# Altium schematic sheet 5V_Efuses.SchDoc(5V_Efuses)
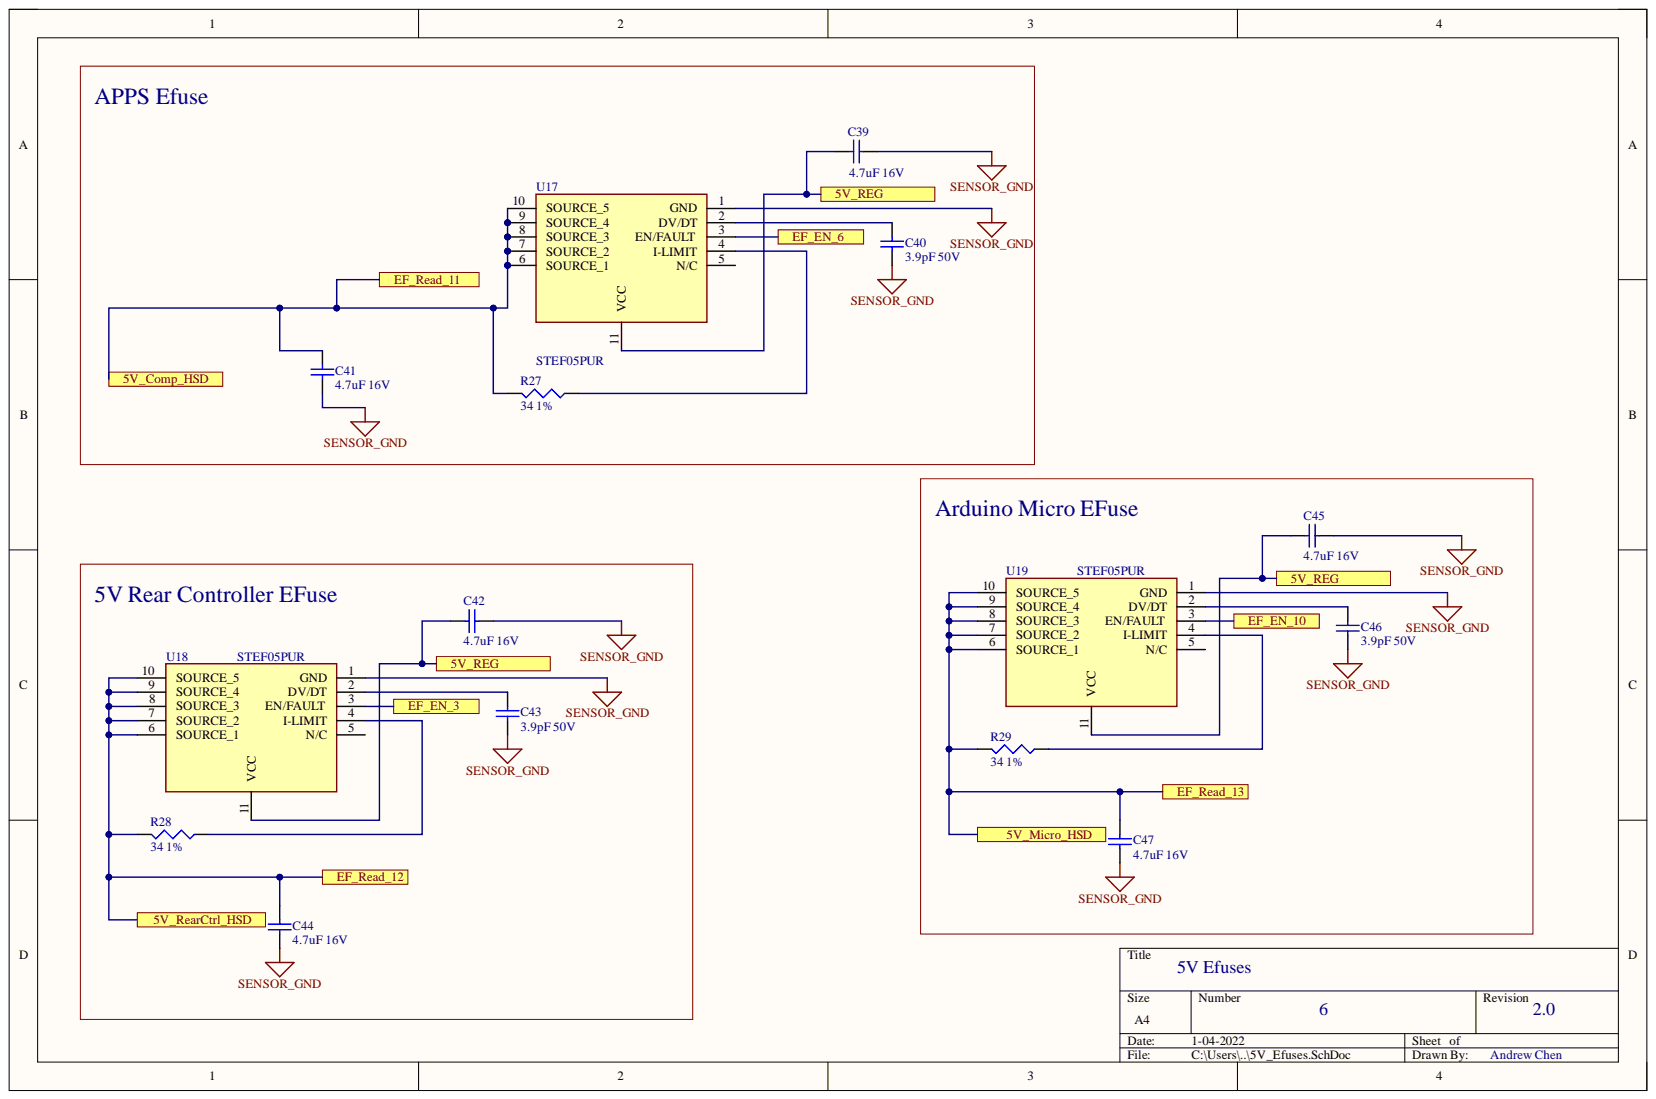
[diagram p0: 5V_Efuses.SchDoc]

## Parts
C39  4.7uF 16V  [C1206]
C40  3.9pF 50V  [C1206]
C41  4.7uF 16V  [C1206]
C42  4.7uF 16V  [C1206]
C43  3.9pF 50V  [C1206]
C44  4.7uF 16V  [C1206]
C45  4.7uF 16V  [C1206]
C46  3.9pF 50V  [C1206]
C47  4.7uF 16V  [C1206]
R27  34 1%  [J1-0603]
R28  34 1%  [J1-0603]
R29  34 1%  [J1-0603]
U17  STEF05PUR  [SON50P300X300X100-11N-D]
U18  STEF05PUR  [SON50P300X300X100-11N-D]
U19  STEF05PUR  [SON50P300X300X100-11N-D]

## Ports
5V_Comp_HSD, 5V_Micro_HSD, 5V_RearCtrl_HSD, 5V_REG, EF_EN_3, EF_EN_6, EF_EN_10, EF_Read_11, EF_Read_12, EF_Read_13

## Nets
SENSOR_GND: C39.2 C40.2 C41.2 C42.2 C43.2 C44.2 C45.2 C46.2 C47.2 U17.1(GND) U18.1(GND) U19.1(GND)
5V_Comp_HSD: C41.1 R27.2 U17.6(SOURCE_1) U17.7(SOURCE_2) U17.8(SOURCE_3) U17.9(SOURCE_4) U17.10(SOURCE_5) ; port 5V_Comp_HSD, port EF_Read_11
5V_Micro_HSD: C47.1 R29.2 U19.6(SOURCE_1) U19.7(SOURCE_2) U19.8(SOURCE_3) U19.9(SOURCE_4) U19.10(SOURCE_5) ; port 5V_Micro_HSD, port EF_Read_13
5V_RearCtrl_HSD: C44.1 R28.2 U18.6(SOURCE_1) U18.7(SOURCE_2) U18.8(SOURCE_3) U18.9(SOURCE_4) U18.10(SOURCE_5) ; port 5V_RearCtrl_HSD, port EF_Read_12
5V_REG: C39.1 C42.1 C45.1 U17.11(VCC) U18.11(VCC) U19.11(VCC) ; port 5V_REG
EF_EN_3: U18.3(EN/FAULT) ; port EF_EN_3
EF_EN_6: U17.3(EN/FAULT) ; port EF_EN_6
EF_EN_10: U19.3(EN/FAULT) ; port EF_EN_10
NetC40_1: C40.1 U17.2(DV/DT)
NetC43_1: C43.1 U18.2(DV/DT)
NetC46_1: C46.1 U19.2(DV/DT)
NetR27_1: R27.1 U17.4(I-LIMIT)
NetR28_1: R28.1 U18.4(I-LIMIT)
NetR29_1: R29.1 U19.4(I-LIMIT)

Not connected: U17 5(N/C); U18 5(N/C); U19 5(N/C)

## Library data of the parts
C39-C47 = Cap Semi: Capacitor (Semiconductor SIM Model); Value: 22pF; Component Kind: Standard; LatestRevisionDate: 29-May-2009; LatestRevisionNote: IPC-7351 Footprint Added.; Library Name: Miscellaneous Devices.IntLib; PackageDescription: Chip Capacitor; PackageReference: C1206; Published: 8-Jun-2000; Signal Integrity: Cap Semi; Simulation: CAP; Publisher: Altium Limited
R27-R29 = Res3: Resistor; Value: 220; Component Kind: Standard; LatestRevisionDate: 29-May-2009; LatestRevisionNote: IPC-7351 Footprint Added.; Library Name: Miscellaneous Devices.IntLib; PackageDescription: Chip Resistor; PackageReference: J1-0603; Published: 8-Jun-2000; Signal Integrity: Res; Simulation: RESISTOR; Publisher: Altium Limited
U17-U19 = STEF12EPUR: Integrated Circuit; Manufacturer_Name: STMicroelectronics; Arrow Part Number: STEF12EPUR; Arrow Price/Stock: https://www.arrow.com/en/products/stef12epur/stmicroelectronics; Component Kind: Standard; Datasheet Link: https://www.arrow.com/en/products/stef12epur/stmicroelectronics; Height: 1mm; Manufacturer_Part_Number: STEF12EPUR; Mouser Part Number: 511-STEF12EPUR; Mouser Price/Stock: https://www.mouser.co.uk/ProductDetail/STMicroelectronics/STEF12EPUR?qs=mKNKSX85ZJfL9n%252BG1BPmuw%3D%3D
